# Altium schematic sheet Power_Input.SchDoc(Power_Input)
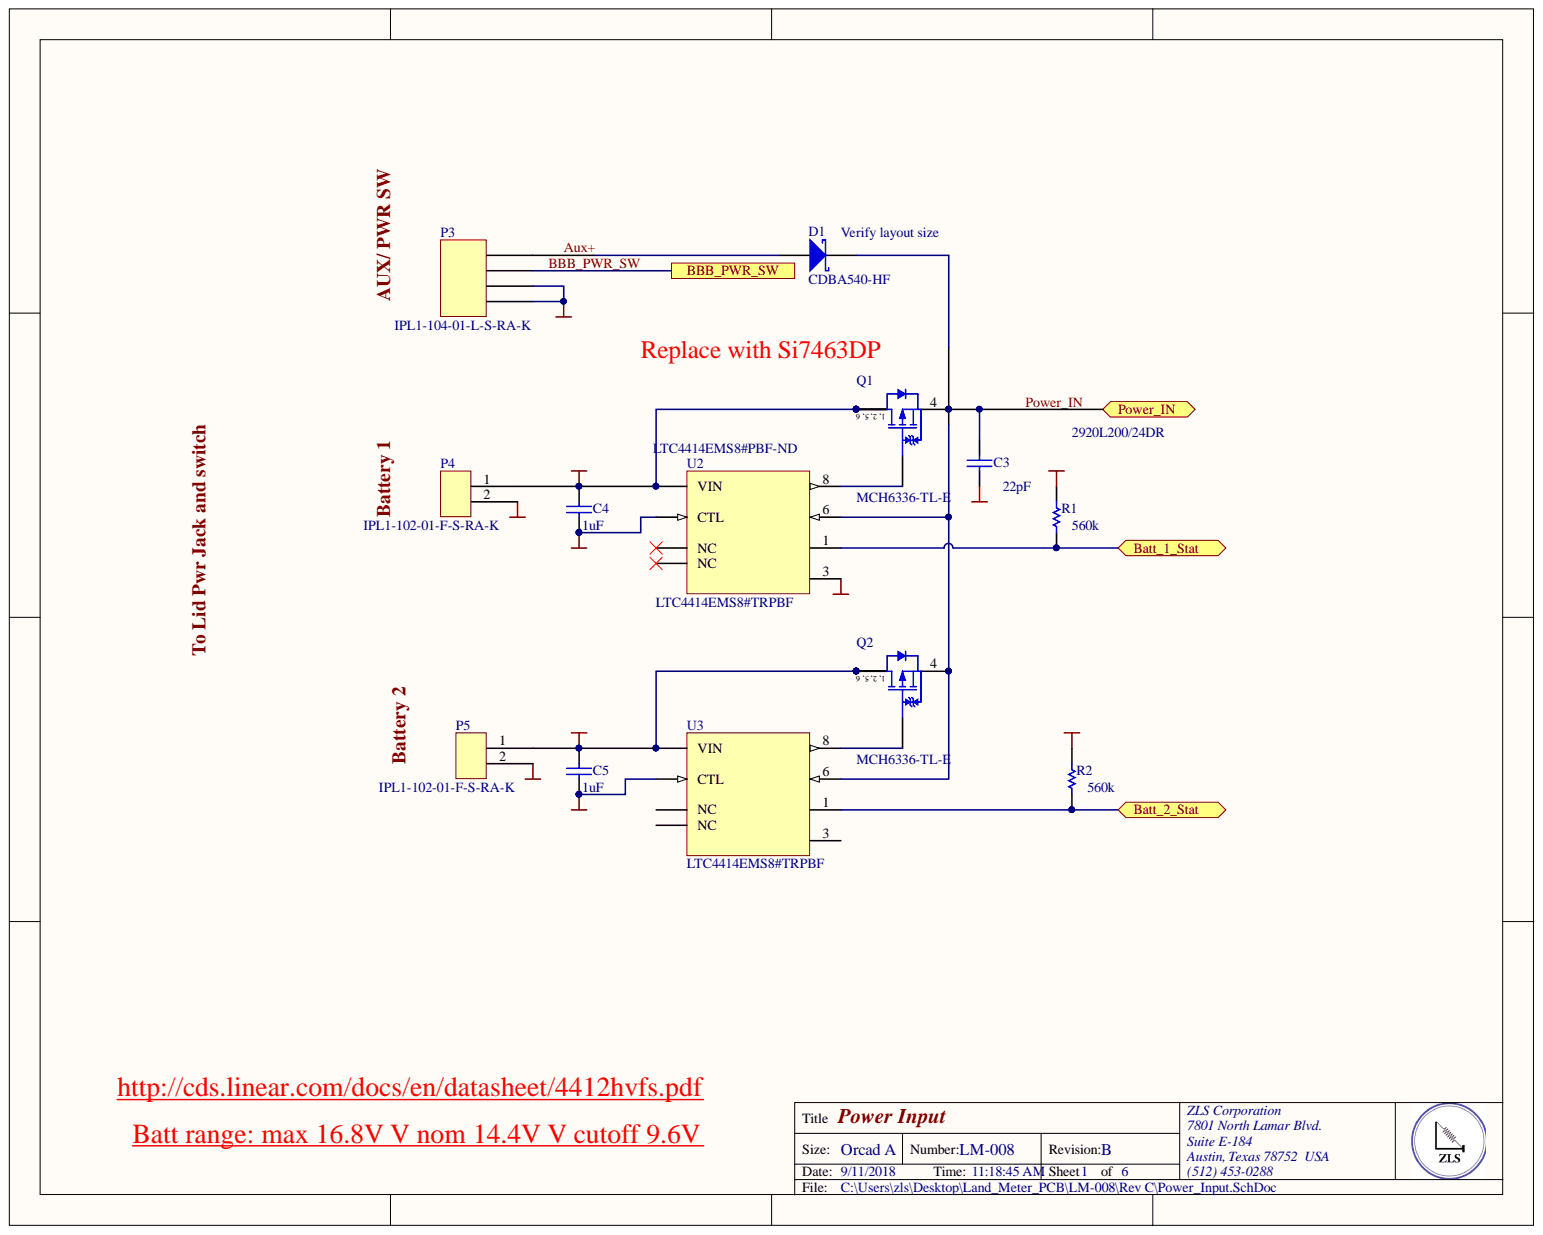
[diagram p0: Power_Input.SchDoc]

## Parts
C3  Capacitance: 22pF  [CAPC1206(3216)130_N]  (hidden comment: CAP CER 10UF 6.3V X5R 1206)
C4  Capacitance: .1uF  [CAPC1206(3216)130_N]  (hidden comment: CAP CER 10UF 6.3V X5R 1206)
C5  Capacitance: .1uF  [CAPC1206(3216)130_N]  (hidden comment: CAP CER 10UF 6.3V X5R 1206)
D1  CDBA540-HF  [VISH-DO-214AC-2_M]
P3  IPL1-104-01-L-S-RA-K  [IPL1-104-01-L-S-RA-K]
P4  IPL1-102-01-F-S-RA-K  [IPL1-102-01-F-S-RA-K]
P5  IPL1-102-01-F-S-RA-K  [IPL1-102-01-F-S-RA-K]
Q1  MCH6336-TL-E  [ONSC-MCPH-6_V]
Q2  MCH6336-TL-E  [ONSC-MCPH-6_V]
R1  560k  [RESC0402(1005)_L]
R2  560k  [RESC0402(1005)_L]
U2  LTC4414EMS8#TRPBF  Supplier Part Number 1: LTC4414EMS8#PBF-ND  [LT-MS8-8_L]
U3  LTC4414EMS8#TRPBF  [LT-MS8-8_L]

## Ports
Batt_1_Stat, Batt_2_Stat, BBB_PWR_SW, Power_IN

## Nets
+3.3: R1.2 R2.2
Battery_1: C4.2 P4.1 Q1.1 Q1.2 Q1.5 Q1.6 U2.7(VIN)
Battery_2: C5.2 P5.1 Q2.1 Q2.2 Q2.5 Q2.6 U3.7(VIN)
GND: C3.1 C4.1 C5.1 P3.3 P3.4 P4.2 P5.2 U2.2(CTL) U2.3 U3.2(CTL)
Aux+: D1.1 P3.1
Batt_1_Stat: R1.1 U2.1 ; port Batt_1_Stat
Batt_2_Stat: R2.1 U3.1 ; port Batt_2_Stat
BBB_PWR_SW: P3.2 ; port BBB_PWR_SW
Power_IN: C3.2 D1.2 Q1.4 Q2.4 U2.6 U3.6 ; port Power_IN
NetQ1_3: Q1.3 U2.8
NetQ2_3: Q2.3 U3.8

Not connected: U2 4(NC) 5(NC); U3 3 4(NC) 5(NC)

## Library data of the parts
C3-C5 = CMP-1037-04913-1: Manufacturer Part Number: JMK316BJ106ML-T; Manufacturer: Taiyo Yuden; Tolerance: ±20%; Notification: Current market demand for these product types have resulted in fluctuating and extending lead times. Lead times may differ.; Voltage - Rated: 6.3V; Thickness (Max): 0.071" (1.80mm); Temperature Coefficient: X5R; Supplier Part Number 1: 587-5447-1-ND; Supplier 1: Digi-Key; Stock 1: 5600; Size / Dimension: 0.126" L x 0.063" W (3.20mm x 1.60mm); RoHS: RoHS Compliant; Ratings: -; Pricing 1: 1=0.24, 10=0.161, 100=0.0817, 500=0.05592, 1000=0.04946 (USD); Part Status: Active; Packaging: Cut Tape (CT); Package / Case: 1206 (3216 Metric); Operating Temperature: -55°C ~ 85°C; Mounting Type: Surface Mount, MLCC; Library Name: Altium Content Vault; Lead Style: -; Lead Spacing: -; Height - Seated (Max): -; Features: -; Failure Rate: -; Description: CAP CER 10UF 6.3V X5R 1206; ComponentLink1URL: http://ds.yuden.co.jp/TYCOMPAS/ut/detail.do?productNo=JMK316BJ106ML-T&fileName=JMK316BJ106ML-T_SS&mode=specSheetDownload; ComponentLink1Description: http://ds.yuden.co.jp/TYCOMPAS/ut/detail.do?productNo=JMK316BJ106ML-T&fileName=JMK316BJ106ML-T_SS&mode=specSheetDownload; Component Kind: Standard; Category: Capacitors; Applications: SMPS Filtering
D1 = D Schottky: DIODE SCHOTTKY 40V 5A DO214AB; Manufacturer: Comchip Technology; Speed: Fast Recovery =< 500ns, > 200mA (Io); Capacitance @ Vr, F: 380pF @ 4V, 1MHz; Supplier Part Number 1: 641-1707-6-ND; Supplier Device Package: DO-214AC (SMA); Supplier 1: Digi-Key; Stock 1: 7367; Simulation: SKYDIODE; Signal Integrity: D Schottky; RoHS: RoHS Compliant; Pricing 1: 1=0.49, 10=0.381, 25=0.3208, 100=0.2612, 250=0.2164, 500=0.1791, 1000=0.13432, 2500=0.12313 (USD); Part Status: Active; Packaging: Digi-Reel®; Package / Case: DO-214AC, SMA; Operating Temperature - Junction: -50°C ~ 150°C; Mounting Type: Surface Mount; Manufacturer Part Number: CDBA540-HF; Library Name: Miscellaneous Devices.IntLib; Diode Type: Schottky; Current - Average Rectified (Io): 5A; ComponentLink1URL: http://www.comchiptech.com/cms/UserFiles/CDBA540-HF%20Thru505315.%20CDBA5200-HF%20RevC.pdf; ComponentLink1Description: http://www.comchiptech.com/cms/UserFiles/CDBA540-HF%20Thru505315.%20CDBA5200-HF%20RevC.pdf; Component Kind: Standard; Category: Discrete Semiconductor Products
P3 = IPL1-104-01-L-S-RA-K: Manufacturer: Samtec Inc.; Voltage Rating: 675VAC, 954VDC; Style: Board to Cable/Wire; Shrouding: Shrouded - 4 Wall; Pitch - Mating: 0.100" (2.54mm); Number of Positions Loaded: All; Number of Positions: 4; Material Flammability Rating: UL94 V-0; Contact Length - Post: 0.085" (2.16mm); Contact Length - Mating: 0.215" (5.46mm); Connector Type: Header; Termination: Solder; Supplier Part Number 1: SAM9597-ND; Supplier 1: Digi-Key; Stock 1: 265; Row Spacing - Mating: -; RoHS: RoHS Compliant; Pricing 1: 1=2.53, 10=2.424, 100=2.02, 500=1.717, 1000=1.4645, 5000=1.313 (USD); Part Status: Active; Packaging: Tube; Overall Contact Length: -; Operating Temperature: -55°C ~ 125°C; Number of Rows: 1; Mounting Type: Through Hole, Right Angle; Mated Stacking Heights: -; Manufacturer Part Number: IPL1-104-01-L-S-RA-K; Insulation Material: Liquid Crystal Polymer (LCP); Insulation Height: 0.240" (6.10mm); Insulation Color: Natural; Ingress Protection: -; Features: -; Fastening Type: Locking Ramp; Description: IPL1-104-01-L-S-RA-K; Current Rating: -; Contact Type: Male Pin; Contact Shape: Square; Contact Material: Phosphor Bronze; Contact Finish Thickness - Post: -; Contact Finish Thickness - Mating: 10µin (0.25µm); Contact Finish - Post: Tin; Contact Finish - Mating: Gold; ComponentLink1URL: http://suddendocs.samtec.com/prints/ipl1-1xx-xx-xxx-s-xx-x-xx-mkt.pdf; ComponentLink1Description: http://suddendocs.samtec.com/prints/ipl1-1xx-xx-xxx-s-xx-x-xx-mkt.pdf; Component Kind: Standard; Category: Connectors, Interconnects; Applications: -; Library Name: ZLS_Schematic_lib.SchLib
P4 P5 = IPL1-102-01-F-S-RA-K: .100 TERMINAL ASSEMBLY; Manufacturer: Samtec Inc.; Voltage Rating: 675VAC, 954VDC; Style: Board to Cable/Wire; Shrouding: Shrouded - 4 Wall; Pitch - Mating: 0.100" (2.54mm); Number of Positions Loaded: All; Number of Positions: 2; Material Flammability Rating: UL94 V-0; Contact Length - Post: 0.085" (2.16mm); Contact Length - Mating: 0.215" (5.46mm); Connector Type: Header; Termination: Solder; Supplier Part Number 1: IPL1-102-01-F-S-RA-K-ND; Supplier 1: Digi-Key; Stock 1: 0; Row Spacing - Mating: -; RoHS: RoHS Compliant; Pricing 1: 1=2.09 (USD); Part Status: Active; Packaging: Tube; Overall Contact Length: -; Operating Temperature: -55°C ~ 125°C; Number of Rows: 1; Mounting Type: Through Hole, Right Angle; Mated Stacking Heights: -; Manufacturer Part Number: IPL1-102-01-F-S-RA-K; Insulation Material: Liquid Crystal Polymer (LCP); Insulation Height: 0.240" (6.10mm); Insulation Color: Natural; Ingress Protection: -; Features: -; Fastening Type: Locking Ramp; Current Rating: -; Contact Type: Male Pin; Contact Shape: Square; Contact Material: Phosphor Bronze; Contact Finish Thickness - Post: -; Contact Finish Thickness - Mating: 3.00µin (0.076µm); Contact Finish - Post: Tin; Contact Finish - Mating: Gold; ComponentLink1URL: http://suddendocs.samtec.com/prints/ipl1-1xx-xx-xxx-s-xx-x-xx-mkt.pdf; ComponentLink1Description: http://suddendocs.samtec.com/prints/ipl1-1xx-xx-xxx-s-xx-x-xx-mkt.pdf; Component Kind: Standard; Category: Connectors, Interconnects; Applications: -; Library Name: ZLS_Schematic_lib.SchLib
Q1 Q2 = CMP-1058-00598-1: PCH 1.8V DRIVE SERIES; Manufacturer: ON Semiconductor; Vgs(th) (Max) @ Id: 1.4V @ 1mA; Vgs (Max): ±10V; Rds On (Max) @ Id, Vgs: 43 mOhm @ 3A, 4.5V; Power Dissipation (Max): 1.5W (Ta); FET Type: P-Channel; Drive Voltage (Max Rds On,  Min Rds On): 1.8V, 4.5V; Current - Continuous Drain (Id) @ 25°C: 5A (Ta); Technology: MOSFET (Metal Oxide); Supplier Part Number 1: MCH6336-TL-EOSCT-ND; Supplier Device Package: SC-88FL/MCPH6; Supplier 1: Digi-Key; Stock 1: 3000; RoHS: Request Inventory Verification; Pricing 1: 1=0.68, 10=0.569, 100=0.4264, 500=0.3127, 1000=0.24164 (USD); Part Status: Active; Packaging: Cut Tape (CT); Package / Case: 6-SMD, Flat Leads; Operating Temperature: 150°C (TJ); Mounting Type: Surface Mount; Manufacturer Part Number: MCH6336-TL-E; Library Name: Altium Content Vault; FET Feature: -; ComponentLink1URL: http://www.onsemi.com/pub/Collateral/MCH6336-D.PDF; ComponentLink1Description: http://www.onsemi.com/pub/Collateral/MCH6336-D.PDF; Component Kind: Standard; Category: Discrete Semiconductor Products
R1 R2 = CMP-1011-00927-1: RES SMD 560K OHM 1% 1/10W 0402; Manufacturer Part Number: ERJ-2RKF5603X; Manufacturer: Panasonic Electronic Components; Temperature Coefficient: ±100ppm/°C; Power (Watts): 0.1W, 1/10W; Tolerance: ±1%; Supplier Part Number 1: P560KLCT-ND; Supplier Device Package: 0402; Supplier 1: Digi-Key; Stock 1: 193515; Size / Dimension: 0.039" L x 0.020" W (1.00mm x 0.50mm); RoHS: RoHS Compliant; Pricing 1: 1=0.1, 10=0.056, 100=0.0227, 1000=0.01021, 2500=0.00886, 5000=0.00732 (USD); Part Status: Active; Packaging: Cut Tape (CT); Package / Case: 0402 (1005 Metric); Operating Temperature: -55°C ~ 155°C; Library Name: Altium Content Vault; Height - Seated (Max): 0.016" (0.40mm); Features: Automotive AEC-Q200; Failure Rate: -; Composition: Thick Film; ComponentLink1URL: https://industrial.panasonic.com/cdbs/www-data/pdf/RDA0000/AOA0000C304.pdf; ComponentLink1Description: https://industrial.panasonic.com/cdbs/www-data/pdf/RDA0000/AOA0000C304.pdf; Component Kind: Standard; Category: Resistors
U2 U3 = CMP-0479-00214-1: IC OR CTRLR SRC SELECT 8MSOP; Manufacturer Part Number: LTC4414EMS8#PBF; Manufacturer: Linear Technology/Analog Devices; Voltage - Supply: 3 V ~ 36 V; Ratio - Input: 2:1; Internal Switch(s): No; FET Type: P-Channel; Type: Source Selector Switch; Supplier Device Package: 8-MSOP; Supplier 1: Digi-Key; Stock 1: 414; RoHS: RoHS Compliant; Pricing 1: 1=3.79, 25=2.6928, 100=2.2236 (USD); Part Status: Active; Packaging: Tube; Package / Case: 8-TSSOP, 8-MSOP (0.118", 3.00mm Width); Operating Temperature: -40°C ~ 85°C; Mounting Type: Surface Mount; Library Name: Altium Content Vault; Current - Output (Max): -; ComponentLink1URL: http://www.linear.com/docs/9037; ComponentLink1Description: http://www.linear.com/docs/9037; Component Kind: Standard; Category: Integrated Circuits (ICs); Base Part Number: LTC4414; Applications: Battery Backup, Industrial/Automotive, High Current Switch
  U3: Supplier Part Number 1: LTC4414EMS8#PBF-ND
